# Altium schematic sheet LED_PROJECT.SchDoc(LED_PROJECT)
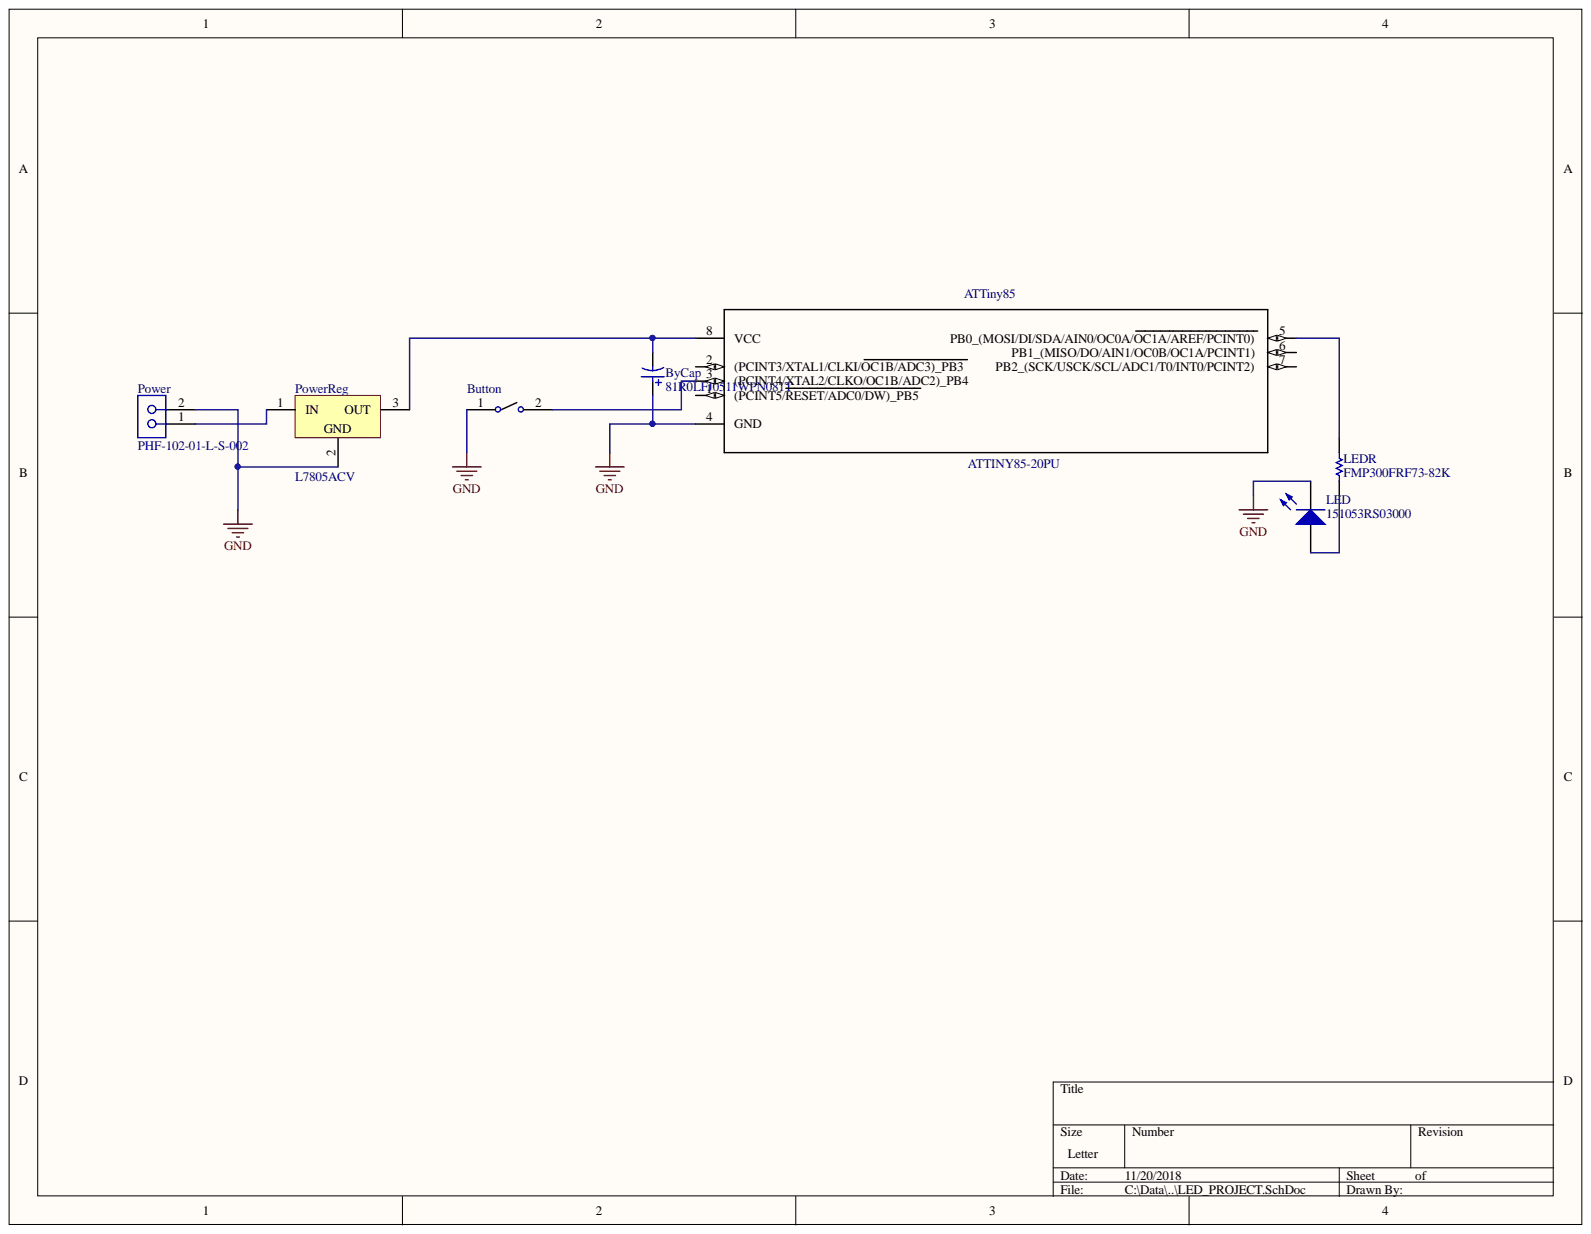
[diagram p0: LED_PROJECT.SchDoc]

## Parts
ATTiny85  ATTINY85-20PU  [DIP254P762X533-8]
Button  147694-1  [TE_FSM8JRT]  (value not drawn)
ByCap  81R0LFJ0511WPN081T  [FHTE-8-0511-2_M]
LED  151053RS03000  [Type 5mm]
LEDR  FMP300FRF73-82K  [YAGO-FMP300-2_V2]
Power  PHF-102-01-L-S-002  [SMTC-PHF-102-01-X-S-002]
PowerReg  L7805ACV  [TO220]

## Nets
GND: ATTiny85.4(GND) Button.1 ByCap.1 LED.1 Power.2 PowerReg.2
NetATTiny85_3: ATTiny85.3((PCINT4/XTAL2/CLKO/OC1B/ADC2)_PB4) Button.2
NetATTiny85_5: ATTiny85.5(PB0_(MOSI/DI/SDA/AIN0/OC0A/OC1A/AREF/PCINT0)) LEDR.2
NetATTiny85_8: ATTiny85.8(VCC) ByCap.2 PowerReg.3
NetLED_2: LED.2 LEDR.1
NetPower_1: Power.1 PowerReg.1

Not connected: ATTiny85 1((PCINT5/RESET/ADC0/DW)_PB5) 2((PCINT3/XTAL1/CLKI/OC1B/ADC3)_PB3) 6(PB1_(MISO/DO/AIN1/OC0B/OC1A/PCINT1)) 7(PB2_(SCK/USCK/SCL/ADC1/T0/INT0/PCINT2))

## Library data of the parts
ATTiny85 = ATTINY85-20PU: ATtiny Series 20 MHz 8 KB Flash 512 B SRAM 8-Bit Microcontroller - DIP-8; MF: Microchip; SnapEDA_Link: https://www.snapeda.com/parts/ATTINY85-20PU/Microchip/view-part/?ref=snap; Price: None; Package: DIP-8 Microchip; MP: ATTINY85-20PU; Library Name: ATTINY85-20PU.SchLib; Component Kind: Standard; Availability: Unavailable
Button = FSM8JRT: FSM8JRT=SWITCH,PUSHBUTTON,TACT; MF: TE Connectivity; Contact_Current_Rating: 50 mA; Configuration_Pole-Throw: Single Pole - Single Throw; TE_purchase_URL: https://www.te.com/usa-en/product-147694-1.html?te_bu=Cor&te_type=disp&te_campaign=seda_glo_cor-seda-global-disp-prtnr-fy19-seda-model-bom-cta_sma-317_1&elqCampaignId=32493; SnapEDA_Link: https://www.snapeda.com/parts/FSM8JRT/TE%20Connectivity%20ALCOSWITCH%20Switches/view-part/?ref=snap; Package: None; MP: FSM8JRT; Library Name: FSM8JRT.IntLib; EU_RoHS_Compliance: Compliant; Digi-Key_Purchase_URL: https://www.digikey.com/product-detail/en/te-connectivity-alcoswitch-switches/FSM8JRT/450-2039-1-ND/4146916?utm_source=snapeda&utm_medium=aggregator&utm_campaign=symbol; Digi-Key_Part_Number: 450-2039-1-ND; Component Kind: Standard
ByCap = CMP-0909-00001-1: Aluminum Electrolytic Capacitor, 1 uF +/- 5%, 50 V, -40 to 105 degC, 2 Pin THD, Dia 5 mm, Body Length 11 mm, Tape and Reel; Manufacturer: Fenghuang Technology; Voltage: 50 V; Tolerance: +/- 5%; Seeve Color: Balck; Nominal Capacitance: 1 uF; PartNumber: 81R0LFJ0511WPN081T; Packing: Tape and Reel; PackageReference: 8-0511-2; PackageDescription: 2-Pin Through Hole Cap, Dia 5 mm, Body Length 11 mm; Operating Temperature: -40 to 105 degC; Mounting Technology: Through Hole; Library Name: Altium Content Vault; DatasheetVersion: Feb-13; ComponentLink2URL: http://218.15.223.226:8089/BusinessProductPdf/ss_20130228152314.pdf; ComponentLink2Description: Datasheet; ComponentLink1URL: http://www.fenghua-advanced.com/; ComponentLink1Description: Manufacturer URL; Component Kind: Standard
LED = CMP-1489-00007-1: THT LED round mono-color waterclear,  WL-TMRW ,Red; Manufacturer: Wuerth Elektronik; PartNumber: 151053RS03000; PackageReference: Type 5mm; Library Name: Altium Content Vault; Emitting color: Red; ComponentLink2URL: http://katalog.we-online.de/pbs/datasheet/7448042001.pdfhttp://katalog.we-online.de/pbs/datasheet/7448042001.pdfhttp://katalog.we-online.de/pbs/datasheet/7448042001.pdfhttp://katalog.we-online.de/pbs/datasheet/151053RS03000.pdf; ComponentLink2Description: Datasheet; ComponentLink1URL: http://www.we-online.com; ComponentLink1Description: Manufacturer URL; Component Kind: Standard
LEDR = CMP-1257-00001-1: Metal Film Resistor, 82 KOhm +/-1%, 3 W, -55 to 155 degC, 2-Pin THD, RoHS, Tape and Reel; Manufacturer: Yageo; Working Voltage: 750 V Max.; Resistance: 82 KOhm; Rated Power: 3 W; Overload Voltage: 1000 V Max.; RoHS: TRUE; Resistance Tolerance: +/-1%; PartNumber: FMP300FRF73-82K; Packing: Tape and Reel; PackageDescription: 2-Pin Axial Lead, Body 15.5 x 5 mm, Lead Spread 33 mm; Operating Temperature: -55 to 155 degC; Mounting Technology: Through Hole; Library Name: Altium Content Vault; DatasheetVersion: Apr-13; ComponentLink3URL: http://www.yageo.com/documents/recent/Yageo%20LR_FMP_2013.pdf; ComponentLink3Description: Package Specification; ComponentLink2URL: http://www.yageo.com/documents/recent/Yageo%20LR_FMP_2013.pdf; ComponentLink2Description: Datasheet; ComponentLink1URL: http://www.yageo.com/NewPortal/_en/index.jsp; ComponentLink1Description: Manufacturer URL; Component Kind: Standard
Power = CMP-1023-00103-1: Press Fit Socket, Through-hole, Vertical, -55 to 125 degC, 2.54 mm Pitch, 2-Pin, Female, RoHS; Manufacturer: Samtec; Pitch: 2.54 mm; Temperature Range: -55 to 125 degC; RoHS: True; PartNumber: PHF-102-01-L-S-002; PackageVersion: F, 9/2009; PackageReference: PHF-102-01-X-S-002; PackageDescription: .100 Press Fit Socket Assembly; Orientation: Vertical; Mounting Technology: Through-hole; Library Name: Altium Content Vault; DatasheetVersion: F-214; ComponentLink3URL: http://www.samtec.com/documents/webfiles/cpdf/PHF-1XX-XX-X-X-XXX-MKT.pdf; ComponentLink3Description: Package Specification; ComponentLink2URL: http://www.samtec.com/documents/webfiles/pdf/phf.pdf; ComponentLink2Description: Datasheet; ComponentLink1URL: http://www.samtec.com; ComponentLink1Description: Manufacturer URL; Component Kind: Standard
PowerReg = CMP-0244-00484-1: Positive Voltage Regulator, 5V, 3-Pin TO-220; PackageVersion: ver3.0; PackageReference: TO220; PackageDescription: TO, Thru-Hole, Vertical, Heatsink Mounted; 3 In-Line Leads; Pitch 2.54 mm; Library Name: Altium Content Vault; DatasheetVersion: 16/11/2011; ComponentLink3URL: http://www.st.com/internet/com/TECHNICAL_RESOURCES/TECHNICAL_LITERATURE/PACKAGE_INFORMATION/CD00004649.pdf; ComponentLink3Description: Package Specification; ComponentLink2URL: http://www.st.com/internet/com/TECHNICAL_RESOURCES/TECHNICAL_LITERATURE/DATASHEET/CD00000444.pdf; ComponentLink2Description: Datasheet; ComponentLink1URL: http://www.st.com; ComponentLink1Description: Manufacturer URL; Component Kind: Standard
